# Altium schematic sheet [04] - ARDUINO NANO 33 IOT Power.SchDoc
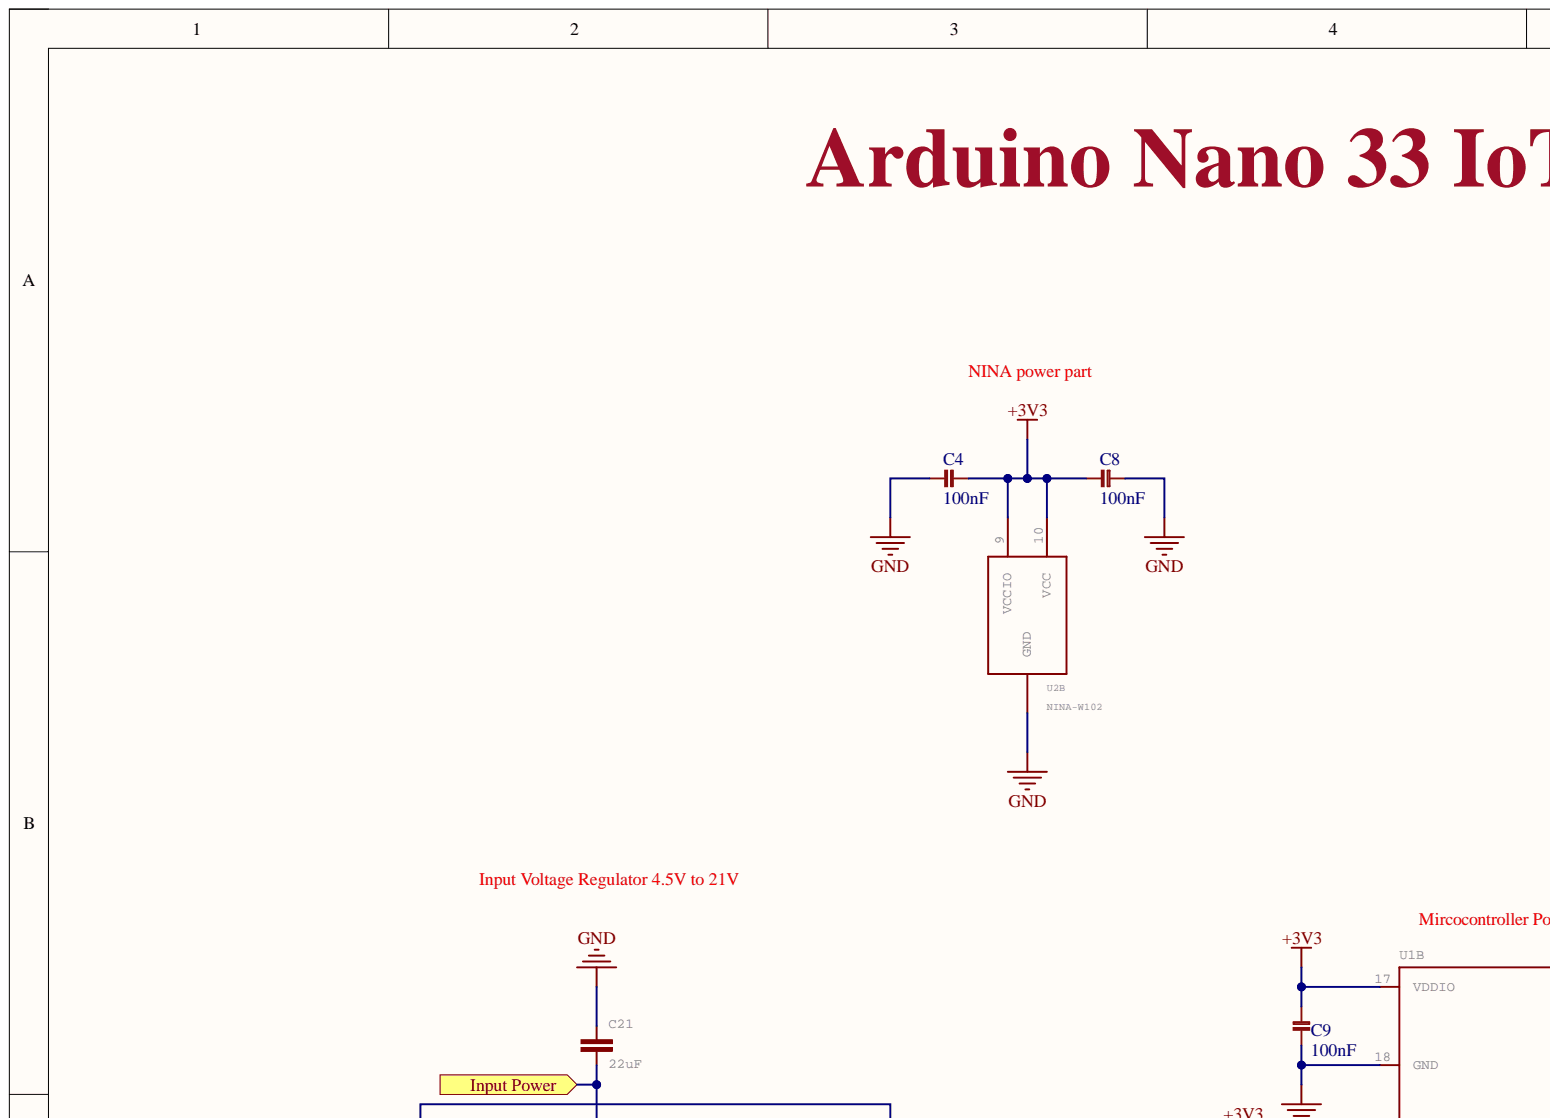
[diagram p0: [04] - ARDUINO NANO 33 IOT Power.SchDoc, top left]
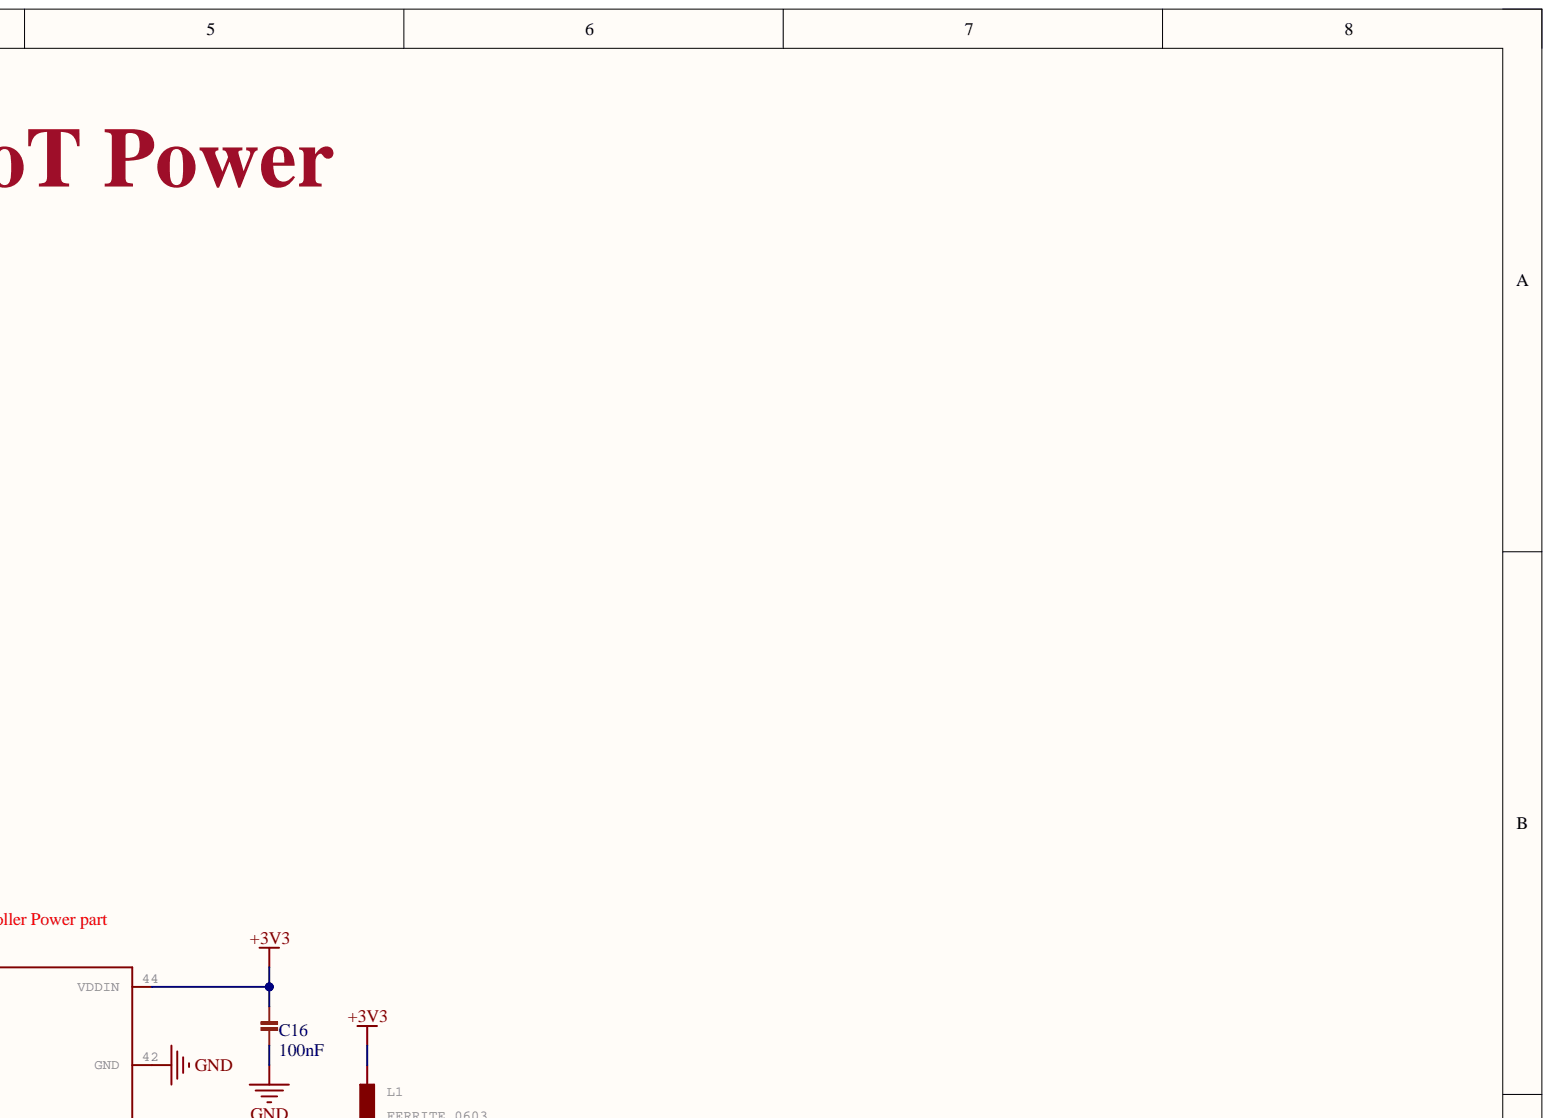
[diagram p1: [04] - ARDUINO NANO 33 IOT Power.SchDoc, top right]
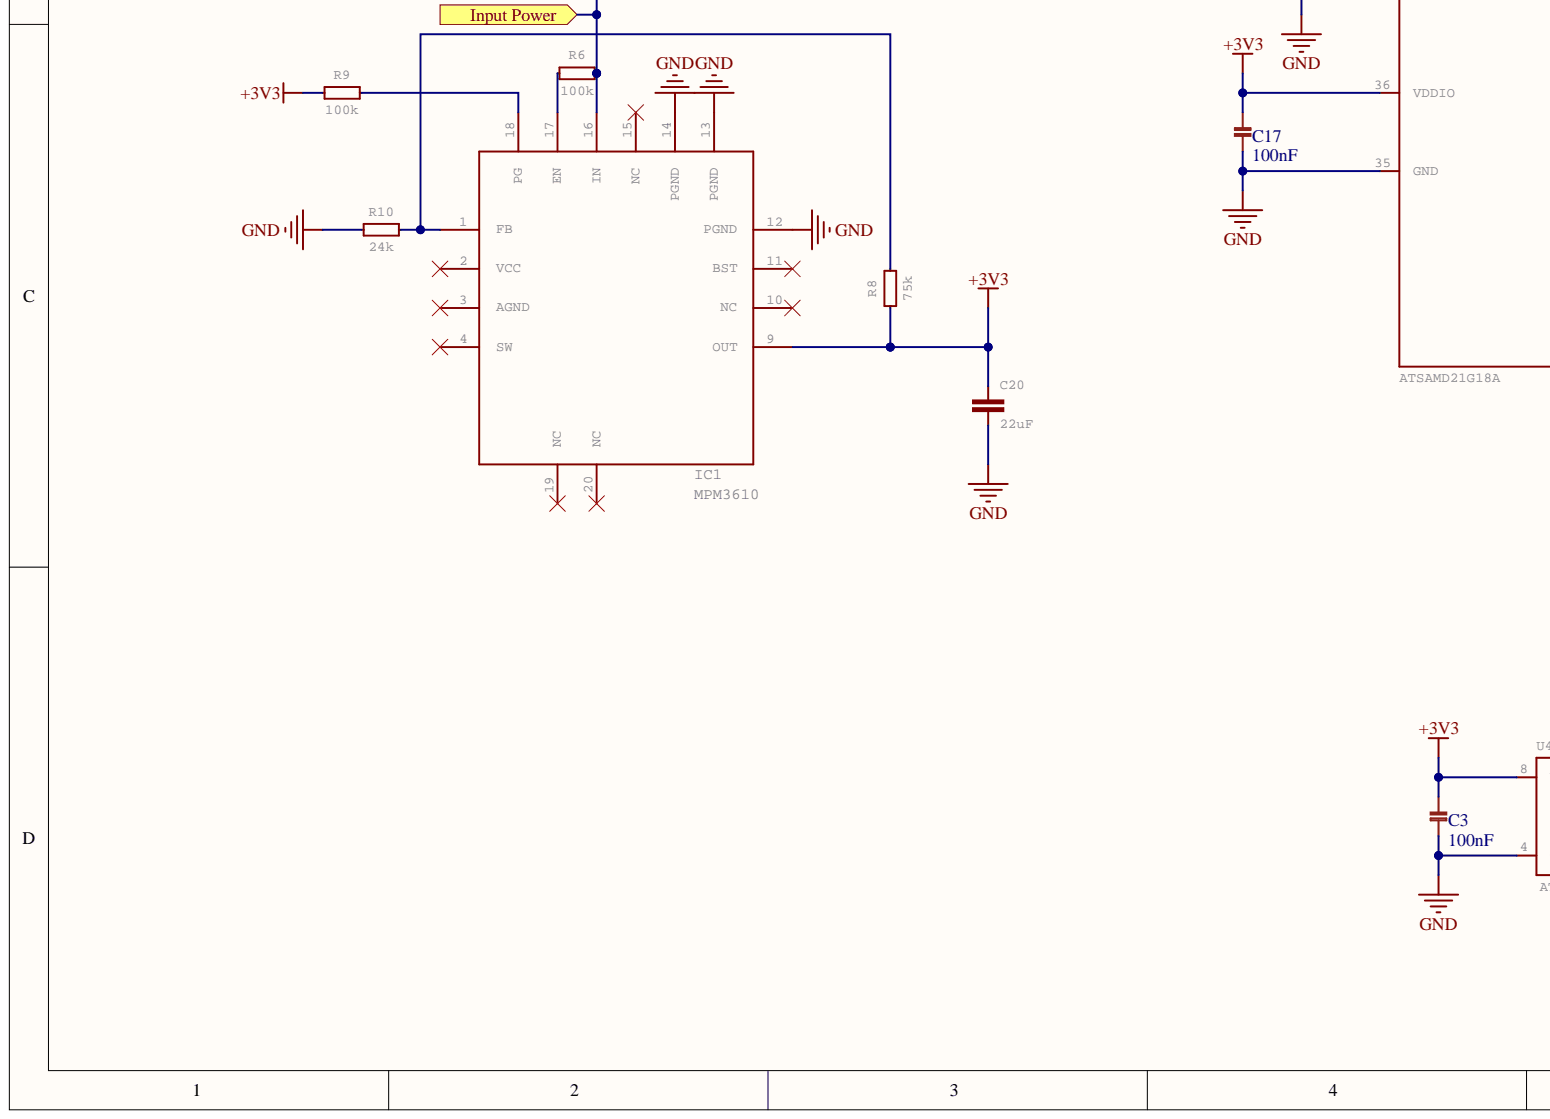
[diagram p2: [04] - ARDUINO NANO 33 IOT Power.SchDoc, bottom left]
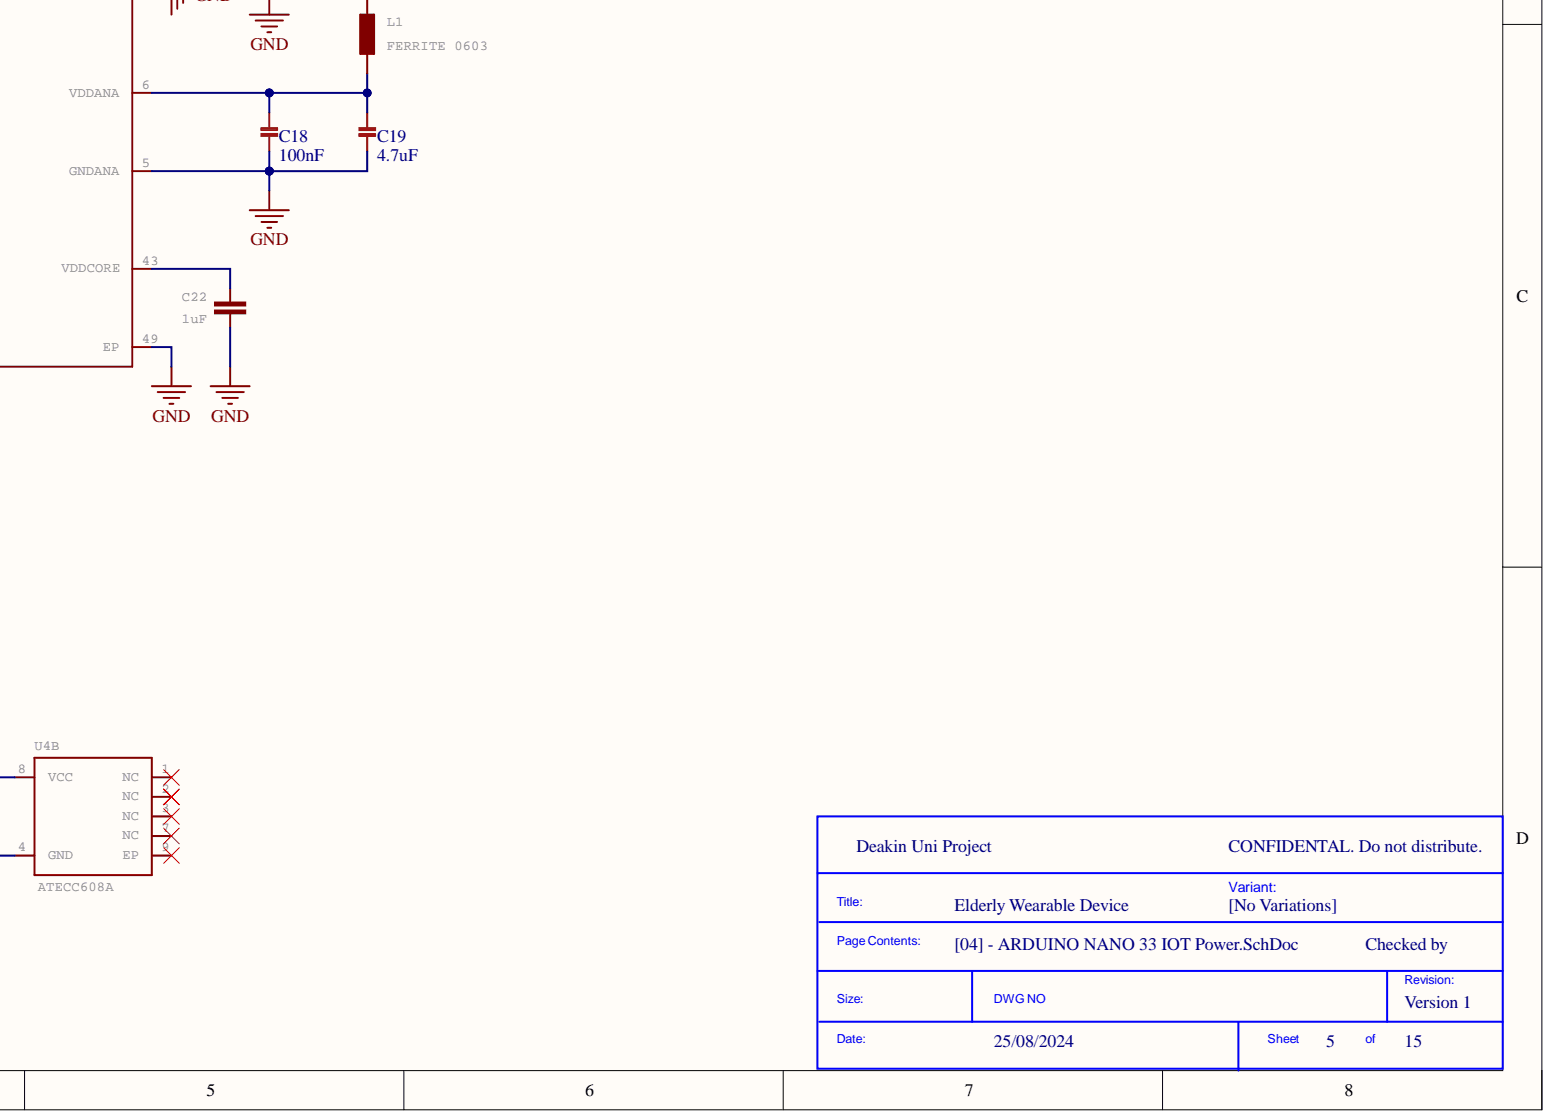
[diagram p3: [04] - ARDUINO NANO 33 IOT Power.SchDoc, bottom right]

## Parts
C3  100nF  [C_0402]
C4  100nF  [C_0402]
C8  100nF  [C_0402]
C9  100nF  [C_0402]
C16  100nF  [C_0402]
C17  100nF  [C_0402]
C18  100nF  [C_0402]
C19  4.7uF  [C_0402]
C20  22uF  [C_0805]
C21  22uF  [C_0805]
C22  1uF  [C_0402]
IC1  MPM3610  [MPM3610]
L1  FERRITE 0603  [Ferrite_0603]
R6  100k  [R_0402]
R8  75k  [R_0402]
R9  100k  [R_0402]
R10  24k  [R_0402]
U1  ATSAMD21G18A  [ATSAMD21G18A]  (part B)
U2  NINA-W102  [NINA-W102-00B]  (part B)
U4  ATECC608A  [ATECC608A]  (part B)

## Ports
Input Power

## Nets
+3V3: C3.2 C4.1 C8.2 C9.1 C16.1 C17.1 C20.1 IC1.9(OUT) L1.1 R8.1 R9.1 U1.17(VDDIO) U1.36(VDDIO) U1.44(VDDIN) U2.9(VCCIO) U2.10(VCC) U4.8(VCC)
GND: C3.1 C4.2 C8.1 C9.2 C16.2 C17.2 C18.2 C19.1 C20.2 C21.1 C22.2 IC1.12(PGND) IC1.13(PGND) IC1.14(PGND) R10.1 U1.5(GNDANA) U1.18(GND) U1.35(GND) U1.42(GND) U1.49(EP) U2.GND U4.4(GND)
Input Power: C21.2 IC1.16(IN) R6.2 ; port Input Power
NetC18_1: C18.1 C19.2 L1.2 U1.6(VDDANA)
NetC22_1: C22.1 U1.43(VDDCORE)
NetIC1_1: IC1.1(FB) R8.2 R10.2
NetIC1_2: IC1.2(VCC)
NetIC1_3: IC1.3(AGND)
NetIC1_4: IC1.4(SW)
NetIC1_10: IC1.10(NC)
NetIC1_11: IC1.11(BST)
NetIC1_15: IC1.15(NC)
NetIC1_17: IC1.17(EN) R6.1
NetIC1_18: IC1.18(PG) R9.2
NetIC1_19: IC1.19(NC)
NetIC1_20: IC1.20(NC)
NetU4_1: U4.1(NC)
NetU4_2: U4.2(NC)
NetU4_3: U4.3(NC)
NetU4_7: U4.7(NC)
NetU4_9: U4.9(EP)

## Library data of the parts
C3 C4 C8 C9 C16-C18 = C_0402_100nF: SMD Capacitor; Manufacturer: Murata Electronics; Manufacturer Part Number: GRM155R71C104KA88J; ALL GOOD: OK_DONE; Component Kind: Standard; Package: 0402; Supplier: Digi-Key; Supplier Part Number: 490-6328-1-ND; Library Name: Elderly_Wearable_Device.SCHLIB
C19 = C_0402_4.7uF: SMD Capacitor; Manufacturer: Samsung Electro-Mechanics; Manufacturer Part Number: CL05A475MP5NRNC; ALL GOOD: OK_DONE; Component Kind: Standard; Package: 0402; Supplier: Digi-Key; Supplier Part Number: 1276-1482-1-ND; Library Name: Elderly_Wearable_Device.SCHLIB
C20 C21 = C_0805_22uF: SMD Capacitor; MANUFACTURER: Samsung Electro-Mechanics; Manufacturer Part Number: CL21A226MOCLRNC; ALL GOOD: OK_DONE; Component Kind: Standard; Package: 0805; Supplier: Digi-Key; Supplier Part Number: 1276-6780-1-ND; Library Name: Elderly_Wearable_Device.SCHLIB
C22 = C_0402_1uF: SMD Capacitor; MANUFACTURER: Samsung Electro-Mechanics; Manufacturer Part Number: CL05A105KO5NNNC; ALL GOOD: OK_DONE; Component Kind: Standard; Package: 0402; Supplier: Digi-Key; Supplier Part Number: 1276-1067-1-ND; Library Name: Elderly_Wearable_Device.SCHLIB
IC1 = MPM3610: MPM3610 Step Down Regulator; MANUFACTURER: Monolithic Power Systems Inc.; Manufacturer Part Number: MPM3610AGQV-P; ALL GOOD: OK_DONE; Component Kind: Standard; Package: 20-PowerLFQFN Module; Supplier: Digi-Key; Supplier Part Number: 1589-1249-1-ND; Library Name: Elderly_Wearable_Device.SCHLIB
L1 = FERRITE 0603: SMD ferrite; MANUFACTURER: Murata Electronics; Manufacturer Part Number: BLM18PG471SN1D; ALL GOOD: OK_DONE; Component Kind: Standard; Package: 0603; Supplier: Digi-Key; Supplier Part Number: 490-5223-1-ND; Library Name: Elderly_Wearable_Device.SCHLIB
R6 R9 = R_0402_100k: SMD Resistor; MANUFACTURER: Yageo; Manufacturer Part Number: RC0402FR-07100KL; ALL GOOD: OK_DONE; Component Kind: Standard; Package: 0402; Supplier: Digi-Key; Supplier Part Number: 311-100KLRCT-ND; Library Name: Elderly_Wearable_Device.SCHLIB
R8 = R_0402_75k: SMD Resistor; MANUFACTURER: Yageo; Manufacturer Part Number: RC0402FR-0775KL; ALL GOOD: OK_DONE; Component Kind: Standard; Package: 0402; Supplier: Digi-Key; Supplier Part Number: 311-75KLRCT-ND; Library Name: Elderly_Wearable_Device.SCHLIB
R10 = R_0402_24k: SMD Resistor; MANUFACTURER: Yageo; Manufacturer Part Number: RC0402FR-0724KL; ALL GOOD: OK_DONE; Component Kind: Standard; Package: 0402; Supplier: Digi-Key; Supplier Part Number: 311-24KLRCT-ND; Library Name: Elderly_Wearable_Device.SCHLIB
U1 = ATSAMD21G18A: ARMÂ® CortexÂ®-M0+ SAM D21G Microcontroller IC 32-Bit 48MHz 256KB (256K x 8) FLASH 48-TQFP (7x7) 48QFN; MANUFACTURER: Microchip Technology; Manufacturer Part Number: ATSAMD21G18A-MU; ALL GOOD: OK_DONE; Component Kind: Standard; Package: 48-VFQFN Exposed Pad; Supplier: Digi-Key; Supplier Part Number: ATSAMD21G18A-MU-ND; Library Name: Elderly_Wearable_Device.SCHLIB
U2 = NINA-W102-00B: ESP32 WiFi/BLE module; MANUFACTURER: u-blox; ALL GOOD: OK_DONE; Component Kind: Standard; Manufacturer Part Number: NINA-W102-00B; Package: 36-SMD Module; Supplier: Digi-Key; Supplier Part Number: 672-NINA-W102-00BCT-ND; Library Name: Elderly_Wearable_Device.SCHLIB
U4 = ATECC608A: Secure authentication and product validation device, EEPROM, I2C, 2.0 - 5.5V; MANUFACTURER: Microchip Technology; Manufacturer Part Number: ATECC608A-MAHDA-T; ALL GOOD: OK_DONE; Component Kind: Standard; Package: 8-UFDFN Exposed Pad; Supplier: Digi-Key; Supplier Part Number: ATECC608A-MAHDA-TCT-ND; Library Name: Elderly_Wearable_Device.SCHLIB
